# Altium schematic sheet LaserMazeTx.SchDoc(LaserMazeTx)
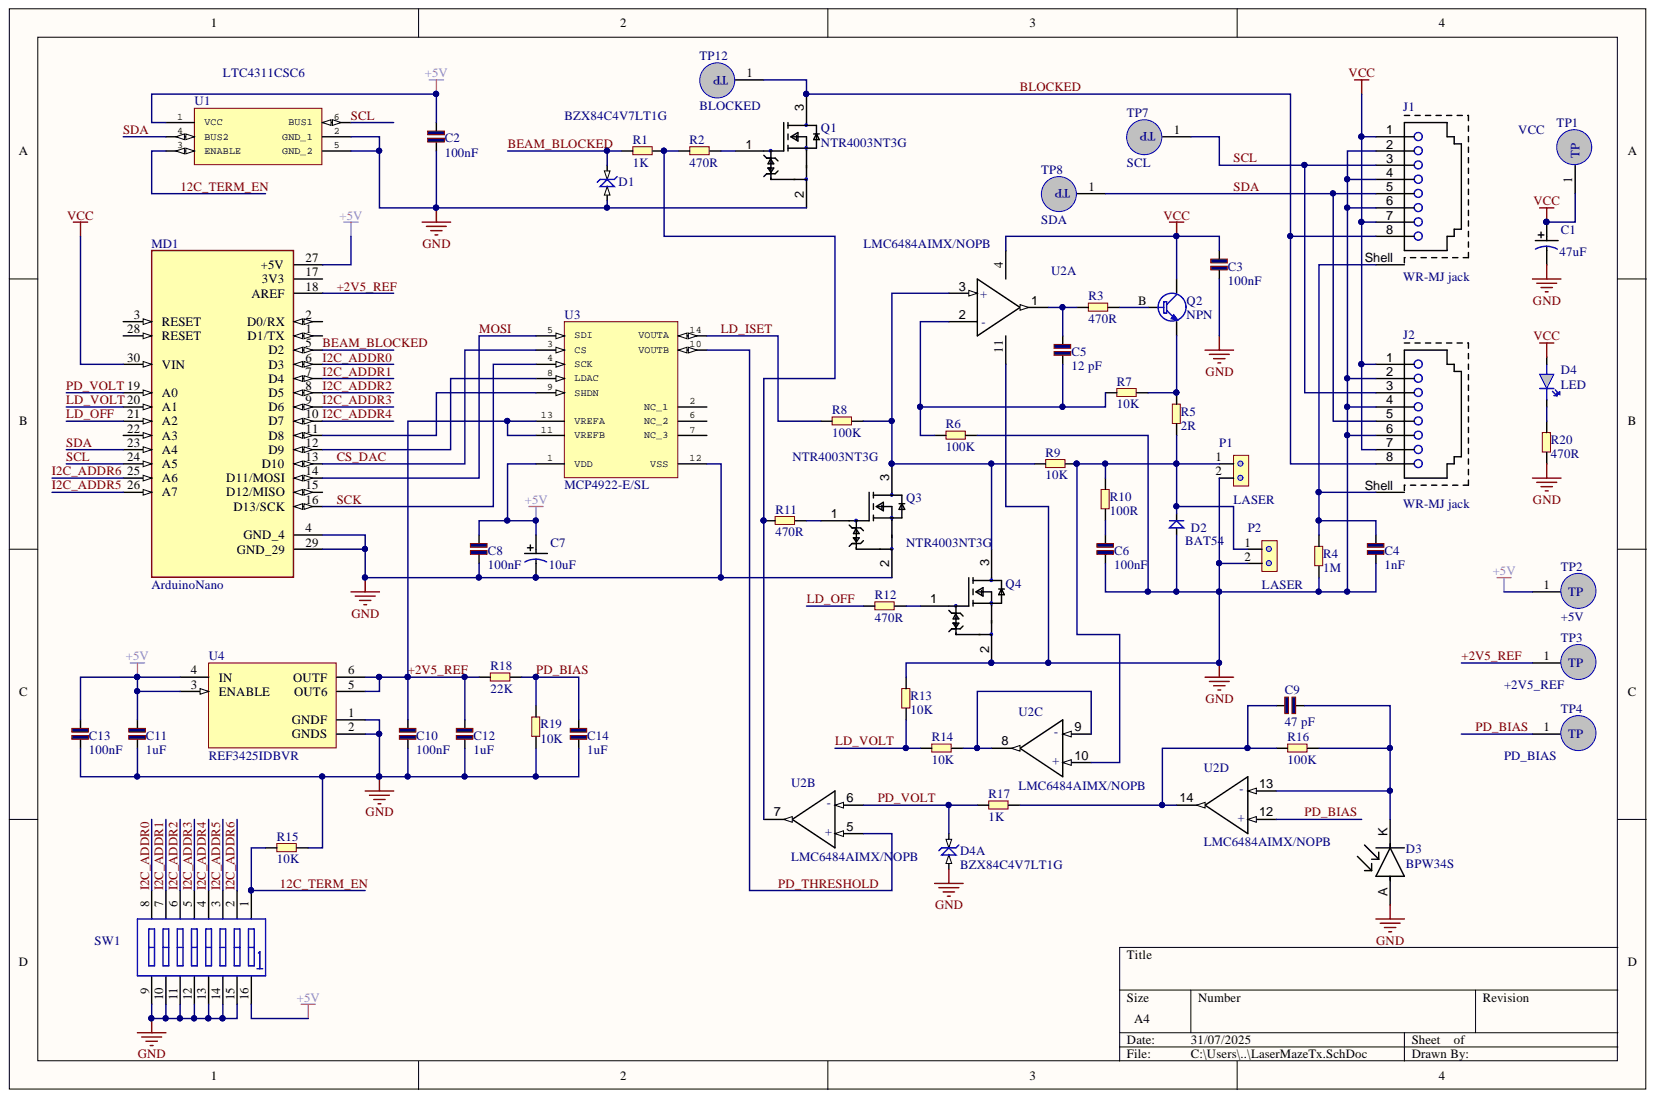
[diagram p0: LaserMazeTx.SchDoc]

## Parts
C1  47uF  [2312 CAP]
C2  100nF  [0805]
C3  100nF  [0805]
C4  1nF  [0805]
C5  12 pF  [0805]
C6  100nF  [0805]
C7  10uF  [2312 CAP]
C8  100nF  [0805]
C9  47 pF  [0805]
C10  100nF  [0805]
C11  1uF  [1206]
C12  1uF  [1206]
C13  100nF  [0805]
C14  1uF  [1206]
D1  BZX84C4V7LT1G  [SOT23_AK_diode]
D2  BAT54  [SOT23_AK_diode]
D3  BPW34S  [BPW34S]
D4  LED  [0805-POL]
D4A  BZX84C4V7LT1G  [SOT23_AK_diode]
J1  WR-MJ jack  [615008140421]
J2  WR-MJ jack  [615008140421]
MD1  ArduinoNano  [ArduinoNano]
P1  LASER  [Header KK-2 pin]
P2  LASER  [Header KK-2 pin]
Q1  NTR4003NT3G  [SOT23 NUM]
Q2  NPN  [SOT-223 cbe]
Q3  NTR4003NT3G  [SOT23 NUM]
Q4  NTR4003NT3G  [SOT23 NUM]
R1  1K  [0805]
R2  470R  [0805]
R3  470R  [0805]
R4  1M  [0805]
R5  2R  [1206]
R6  100K  [0805]
R7  10K  [0805]
R8  100K  [0805]
R9  10K  [0805]
R10  100R  [0805]
R11  470R  [0805]
R12  470R  [0805]
R13  10K  [0805]
R14  10K  [0805]
R15  10K  [0805]
R16  100K  [0805]
R17  1K  [0805]
R18  22K  [0805]
R19  10K  [0805]
R20  470R  [0805]
SW1  DIPSWITCH_8_SMT  [SO16W]  (value not drawn)
TP1  VCC  [Testpoint]
TP2  +5V  [Testpoint]
TP3  +2V5_REF  [Testpoint]
TP4  PD_BIAS  [Testpoint]
TP7  SCL  [Testpoint]
TP8  SDA  [Testpoint]
TP12  BLOCKED  [Testpoint]
U1  LTC4311CSC6  [SOT65P210X100-6N]
U2  LMC6484AIMX/NOPB  [SOIC127P600X175-14N]  (parts A,B,C,D)
U3  MCP4922-E/SL  [SOIC127P600X175-14N]
U4  REF3425IDBVR  [SOT23-6pin]

## Nets
+5V: C2.1 C7.1 C8.1 C11.1 C13.1 MD1.27(+5V) SW1.16 TP2.1 U1.1(VCC) U3.1(VDD) U4.3(ENABLE) U4.4(IN)
GND: C1.2 C2.2 C3.2 C4.1 C6.2 C7.2 C8.2 C10.2 C11.2 C12.2 C13.2 C14.2 D1.A D2.A D3.A D4A.A J1.2 J1.4 J1.6 J2.2 J2.4 J2.6 MD1.4(GND_4) MD1.29(GND_29) P1.2 P2.2 Q1.2 Q3.2 Q4.2 R4.1 R6.2 R13.2 R15.2 R19.2 R20.1 SW1.9 SW1.10 SW1.11 SW1.12 SW1.13 SW1.14 SW1.15 U1.2(GND_1) U1.5(GND_2) U3.12(VSS) U4.1(GNDF) U4.2(GNDS)
VCC: C1.1 C3.1 D4.A J1.1 J1.7 J2.1 J2.7 MD1.30(VIN) Q2.C TP1.1
12C_TERM_EN: R15.1 SW1.1 U1.3(ENABLE)
+2V5_REF: C10.1 C12.1 MD1.18(AREF) R18.1 TP3.1 U3.11(VREFB) U3.13(VREFA) U4.5(OUT6) U4.6(OUTF)
BEAM_BLOCKED: D1.K MD1.5(D2) R1.1
BLOCKED: J1.8 J2.8 Q1.3 TP12.1
CS_DAC: MD1.13(D10) U3.3(CS)
I2C_ADDR0: MD1.6(D3) SW1.8
I2C_ADDR1: MD1.7(D4) SW1.7
I2C_ADDR2: MD1.8(D5) SW1.6
I2C_ADDR3: MD1.9(D6) SW1.5
I2C_ADDR4: MD1.10(D7) SW1.4
I2C_ADDR5: MD1.26(A7) SW1.3
I2C_ADDR6: MD1.25(A6) SW1.2
LD_ISET: R8.1 U3.14(VOUTA)
LD_OFF: MD1.21(A2) R12.1
LD_VOLT: MD1.20(A1) R13.1 R14.1
MOSI: MD1.14(D11/MOSI) U3.5(SDI)
PD_BIAS: C14.1 R18.2 R19.1 TP4.1 U2.12(+)
PD_THRESHOLD: U2.5(+) U3.10(VOUTB)
PD_VOLT: D4A.K MD1.19(A0) R17.1 U2.6(-)
SCK: MD1.16(D13/SCK) U3.4(SCK)
SCL: J1.3 J2.3 MD1.24(A5) TP7.1 U1.6(BUS1)
SDA: J1.5 J2.5 MD1.23(A4) TP8.1 U1.4(BUS2)
NetC4_2: C4.2 J1.Shell J2.Shell R4.2
NetC5_1: C5.1 R3.1 U2.1
NetC5_2: C5.2 R6.1 R7.1 U2.2(-)
NetC6_1: C6.1 R10.1
NetC9_1: C9.1 R16.1 R17.2 U2.14
NetC9_2: C9.2 D3.K R16.2 U2.13(-)
NetD2_K: D2.K P1.1 P2.1 R5.1 R9.2 R10.2 U2.10(+)
NetD4_K: D4.K R20.2
NetMD1_11: MD1.11(D8) U3.9(SHDN)
NetMD1_12: MD1.12(D9) U3.8(LDAC)
NetQ1_1: Q1.1 R2.2
NetQ2_B: Q2.B R3.2
NetQ2_E: Q2.E R5.2 R7.2
NetQ3_1: Q3.1 R11.2
NetQ3_3: Q3.3 Q4.3 R8.2 R9.1 U2.3(+)
NetQ4_1: Q4.1 R12.2
NetR1_2: R1.2 R2.1 R11.1 U2.7
NetR14_2: R14.2 U2.8 U2.9(-)

Not connected: MD1 1(D1/TX) 2(D0/RX) 3(RESET) 15(D12/MISO) 17(3V3) 22(A3) 28(RESET); U2 4 11; U3 2(NC_1) 6(NC_2) 7(NC_3)

## Library data of the parts
C1 C7 = CAP-ELECTRO: 6.3 x 5.4 mm case; Component Kind: Standard; Library Name: RusconX.LIB
C2-C6 C8-C14 = CAP: SMT ceramic capacitor; Component Kind: Standard; Library Name: RusconX.LIB
D1 D4A = ZENER-SMT: 4.7 V Zener Diode SOT-23; Component Kind: Standard; Library Name: RusconX.LIB
D2 = DIODE: DIODE (various); Component Kind: Standard; Library Name: RusconX.LIB
D3 = BPW34S: BPW34S - optical sensor; Component Kind: Standard; Library Name: RusconX.LIB
D4 = LED: LED 0805/1206; Component Kind: Standard; Library Name: RusconX.LIB
J1 J2 = RJ45: WR-MJ Rear Post Modular Jack  Vertical; Component Kind: Standard; Library Name: RusconX.LIB
MD1 = ArduinoNano: Arduino Nano module; Component Kind: Standard; Library Name: RusconX.LIB
P1 P2 = CONN2: 2 Pin (STL130)  5mm pitch; Text Field2: Adilam; Component Kind: Standard; Library Name: RusconX.LIB
Q1 Q3 Q4 = MOSFET-2: MOSFET-N - numbered; Component Kind: Standard; Library Name: RusconX.LIB
Q2 = NPN: NPN SOT23 transistor; Component Kind: Standard; Library Name: RusconX.LIB
R1-R20 = RES: Resistor; Text Field2: IRH; Text Field1: IRC; Component Kind: Standard; Library Name: RusconX.LIB
SW1 = DIPSWITCH_8_SMT: half pitch dipswitch (8); Text Field2: Alfa Kilo Services Nick (9901 3770); Text Field1: Diptronics HDS08; Component Kind: Standard; Library Name: RusconX.LIB
TP1-TP4 TP7 TP8 TP12 = TestPoint: Description: Testpoint; Component Kind: Standard; Library Name: RusconX.LIB
U1 = LTC4311CSC6#TRPBF: I2C/SMBus Accelerator; Component Kind: Standard; Library Name: RusconX.LIB
U4 = REF3425IDBVR: Low-Drift, Low-Power, Small-Footprint Series Voltage Reference; Component Kind: Standard; Library Name: RusconX.LIB
U2 = LMC6484AIMX/NOPB: Component Kind: Standard; Library Name: RusconX.LIB
U3 = MCP4922-E/SL: Component Kind: Standard; Library Name: RusconX.LIB
